# Altium schematic sheet main.SchDoc
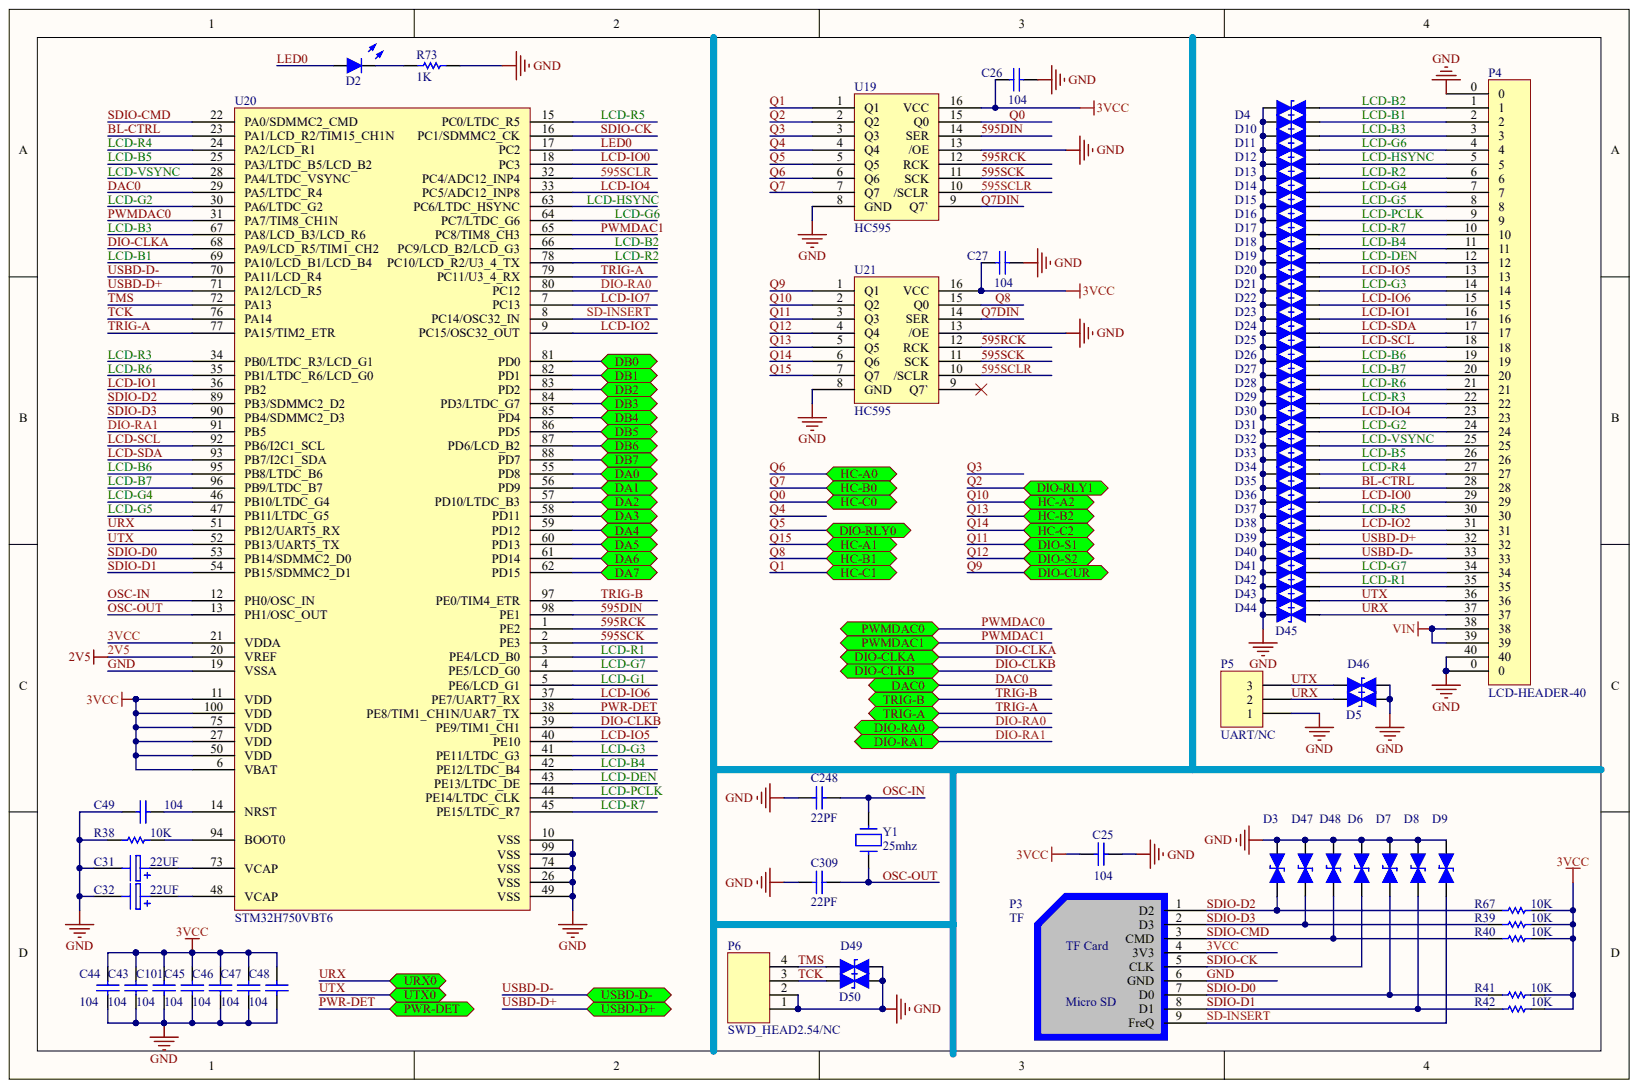
[diagram p0: main.SchDoc]

## Parts
C25  C104  (value not drawn)
C26  C104  (value not drawn)
C27  C104  (value not drawn)
C31  22UF
C32  22UF
C43  C104  (value not drawn)
C44  C104  (value not drawn)
C45  C104  (value not drawn)
C46  C104  (value not drawn)
C47  C104  (value not drawn)
C48  C104  (value not drawn)
C49  C104  (value not drawn)
C101  C104  (value not drawn)
C248  22PF/NC  (value not drawn)
C309  22PF/NC  (value not drawn)
D2  LED-B  (value not drawn)
D3  ESD_0402  (value not drawn)
D4  ESD_0402  (value not drawn)
D5  ESD_0402  (value not drawn)
D6  ESD_0402  (value not drawn)
D7  ESD_0402  (value not drawn)
D8  ESD_0402  (value not drawn)
D9  ESD_0402  (value not drawn)
D10  ESD_0402  (value not drawn)
D11  ESD_0402  (value not drawn)
D12  ESD_0402  (value not drawn)
D13  ESD_0402  (value not drawn)
D14  ESD_0402  (value not drawn)
D15  ESD_0402  (value not drawn)
D16  ESD_0402  (value not drawn)
D17  ESD_0402  (value not drawn)
D18  ESD_0402  (value not drawn)
D19  ESD_0402  (value not drawn)
D20  ESD_0402  (value not drawn)
D21  ESD_0402  (value not drawn)
D22  ESD_0402  (value not drawn)
D23  ESD_0402  (value not drawn)
D24  ESD_0402  (value not drawn)
D25  ESD_0402  (value not drawn)
D26  ESD_0402  (value not drawn)
D27  ESD_0402  (value not drawn)
D28  ESD_0402  (value not drawn)
D29  ESD_0402  (value not drawn)
D30  ESD_0402  (value not drawn)
D31  ESD_0402  (value not drawn)
D32  ESD_0402  (value not drawn)
D33  ESD_0402  (value not drawn)
D34  ESD_0402  (value not drawn)
D35  ESD_0402  (value not drawn)
D36  ESD_0402  (value not drawn)
D37  ESD_0402  (value not drawn)
D38  ESD_0402  (value not drawn)
D39  ESD_0402  (value not drawn)
D40  ESD_0402  (value not drawn)
D41  ESD_0402  (value not drawn)
D42  ESD_0402  (value not drawn)
D43  ESD_0402  (value not drawn)
D44  ESD_0402  (value not drawn)
D45  ESD_0402  (value not drawn)
D46  ESD_0402  (value not drawn)
D47  ESD_0402  (value not drawn)
D48  ESD_0402  (value not drawn)
D49  ESD_0402  (value not drawn)
D50  ESD_0402  (value not drawn)
P3  TF
P4  LCD-HEADER-40
P5  UART/NC
P6  SWD_HEAD2.54/NC
R38  10K
R39  10K
R40  10K
R41  10K
R42  10K
R67  10K
R73  1K
U19  HC595
U20  STM32H750VBT6
U21  HC595
Y1  25mhz

## Ports
DA0, DA1, DA2, DA3, DA4, DA5, DA6, DA7, DAC0, DB0, DB1, DB2, DB3, DB4, DB5, DB6, DB7, DIO-CLKA, DIO-CLKB, DIO-CUR, DIO-RA0, DIO-RA1, DIO-RLY0, DIO-RLY1, DIO-S1, DIO-S2, HC-A0, HC-A1, HC-A2, HC-B0, HC-B1, HC-B2, HC-C0, HC-C1, HC-C2, PWMDAC0, PWMDAC1, PWR-DET, TRIG-A, TRIG-B, URX0, USBD-D+, USBD-D-, UTX0

## Nets
VIN: P4.38 P4.39
2V5: U20.20(VREF)
3VCC: C25.1 C26.1 C27.2 C43.2 C44.2 C45.2 C46.2 C47.2 C48.2 C101.2 P3.4(3V3) R39.2 R40.2 R41.2 R42.2 R67.2 U19.16(VCC) U20.6(VBAT) U20.11(VDD) U20.21(VDDA) U20.27(VDD) U20.50(VDD) U20.75(VDD) U20.100(VDD) U21.16(VCC)
595DIN: U19.14(SER) U20.98(PE1)
595RCK: U19.12(RCK) U20.1(PE2) U21.12(RCK)
595SCK: U19.11(SCK) U20.2(PE3) U21.11(SCK)
595SCLR: U19.10(/SCLR) U20.32(PC4/ADC12_INP4) U21.10(/SCLR)
BL-CTRL: D36.2 P4.28 U20.23(PA1/LCD_R2/TIM15_CH1N)
DA0: U20.55(PD8) ; port DA0
DA1: U20.56(PD9) ; port DA1
DA2: U20.57(PD10/LTDC_B3) ; port DA2
DA3: U20.58(PD11) ; port DA3
DA4: U20.59(PD12) ; port DA4
DA5: U20.60(PD13) ; port DA5
DA6: U20.61(PD14) ; port DA6
DA7: U20.62(PD15) ; port DA7
DAC0: U20.29(PA5/LTDC_R4) ; port DAC0
DB0: U20.81(PD0) ; port DB0
DB1: U20.82(PD1) ; port DB1
DB2: U20.83(PD2) ; port DB2
DB3: U20.84(PD3/LTDC_G7) ; port DB3
DB4: U20.85(PD4) ; port DB4
DB5: U20.86(PD5) ; port DB5
DB6: U20.87(PD6/LCD_B2) ; port DB6
DB7: U20.88(PD7) ; port DB7
DIO-CLKA: U20.68(PA9/LCD_R5/TIM1_CH2) ; port DIO-CLKA
DIO-CLKB: U20.39(PE9/TIM1_CH1) ; port DIO-CLKB
DIO-RA0: U20.80(PC12) ; port DIO-RA0
DIO-RA1: U20.91(PB5) ; port DIO-RA1
GND: C25.2 C26.2 C27.1 C31.2 C32.2 C43.1 C44.1 C45.1 C46.1 C47.1 C48.1 C49.2 C101.1 C248.2 C309.2 D3.1 D4.1 D5.1 D6.1 D7.1 D8.1 D9.1 D10.1 D11.1 D12.1 D13.1 D14.1 D15.1 D16.1 D17.1 D18.1 D19.1 D20.1 D21.1 D22.1 D23.1 D24.1 D25.1 D26.1 D27.1 D28.1 D29.1 D30.1 D31.1 D32.1 D33.1 D34.1 D35.1 D36.1 D37.1 D38.1 D39.1 D40.1 D41.1 D42.1 D43.1 D44.1 D45.1 D46.1 D47.1 D48.1 D49.2 D50.2 P3.6(GND) P4.0 P4.40 P5.1 P6.1 P6.2 R38.1 R73.2 U19.8(GND) U19.13(/OE) U20.10(VSS) U20.19(VSSA) U20.26(VSS) U20.49(VSS) U20.74(VSS) U20.99(VSS) U21.8(GND) U21.13(/OE)
LCD-B1: D10.2 P4.2 U20.69(PA10/LCD_B1/LCD_B4)
LCD-B2: D4.2 P4.1 U20.66(PC9/LCD_B2/LCD_G3)
LCD-B3: D11.2 P4.3 U20.67(PA8/LCD_B3/LCD_R6)
LCD-B4: D19.2 P4.11 U20.42(PE12/LTDC_B4)
LCD-B5: D34.2 P4.26 U20.25(PA3/LTDC_B5/LCD_B2)
LCD-B6: D27.2 P4.19 U20.95(PB8/LTDC_B6)
LCD-B7: D28.2 P4.20 U20.96(PB9/LTDC_B7)
LCD-DEN: D20.2 P4.12 U20.43(PE13/LTDC_DE)
LCD-G1: U20.5(PE6/LCD_G1)
LCD-G2: D32.2 P4.24 U20.30(PA6/LTDC_G2)
LCD-G3: D22.2 P4.14 U20.41(PE11/LTDC_G3)
LCD-G4: D15.2 P4.7 U20.46(PB10/LTDC_G4)
LCD-G5: D16.2 P4.8 U20.47(PB11/LTDC_G5)
LCD-G6: D12.2 P4.4 U20.64(PC7/LTDC_G6)
LCD-G7: D42.2 P4.34 U20.4(PE5/LCD_G0)
LCD-HSYNC: D13.2 P4.5 U20.63(PC6/LTDC_HSYNC)
LCD-IO0: D37.2 P4.29 U20.18(PC3)
LCD-IO1: D24.2 P4.16 U20.36(PB2)
LCD-IO2: D39.2 P4.31 U20.9(PC15/OSC32_OUT)
LCD-IO4: D31.2 P4.23 U20.33(PC5/ADC12_INP8)
LCD-IO5: D21.2 P4.13 U20.40(PE10)
LCD-IO6: D23.2 P4.15 U20.37(PE7/UART7_RX)
LCD-IO7: U20.7(PC13)
LCD-PCLK: D17.2 P4.9 U20.44(PE14/LTDC_CLK)
LCD-R1: D43.2 P4.35 U20.3(PE4/LCD_B0)
LCD-R2: D14.2 P4.6 U20.78(PC10/LCD_R2/U3_4_TX)
LCD-R3: D30.2 P4.22 U20.34(PB0/LTDC_R3/LCD_G1)
LCD-R4: D35.2 P4.27 U20.24(PA2/LCD_R1)
LCD-R5: D38.2 P4.30 U20.15(PC0/LTDC_R5)
LCD-R6: D29.2 P4.21 U20.35(PB1/LTDC_R6/LCD_G0)
LCD-R7: D18.2 P4.10 U20.45(PE15/LTDC_R7)
LCD-SCL: D26.2 P4.18 U20.92(PB6/I2C1_SCL)
LCD-SDA: D25.2 P4.17 U20.93(PB7/I2C1_SDA)
LCD-VSYNC: D33.2 P4.25 U20.28(PA4/LTDC_VSYNC)
LED0: D2.1 U20.17(PC2)
OSC-IN: C248.1 U20.12(PH0/OSC_IN) Y1.1
OSC-OUT: C309.1 U20.13(PH1/OSC_OUT) Y1.3
PWMDAC0: U20.31(PA7/TIM8_CH1N) ; port PWMDAC0
PWMDAC1: U20.65(PC8/TIM8_CH3) ; port PWMDAC1
PWR-DET: U20.38(PE8/TIM1_CH1N/UAR7_TX) ; port PWR-DET
Q0: U19.15(Q0) ; port HC-C0
Q1: U19.1(Q1) ; port HC-C1
Q2: U19.2(Q2) ; port DIO-RLY1
Q3: U19.3(Q3)
Q4: U19.4(Q4)
Q5: U19.5(Q5) ; port DIO-RLY0
Q6: U19.6(Q6) ; port HC-A0
Q7: U19.7(Q7) ; port HC-B0
Q7DIN: U19.9(Q7`) U21.14(SER)
Q8: U21.15(Q0) ; port HC-B1
Q9: U21.1(Q1) ; port DIO-CUR
Q10: U21.2(Q2) ; port HC-A2
Q11: U21.3(Q3) ; port DIO-S1
Q12: U21.4(Q4) ; port DIO-S2
Q13: U21.5(Q5) ; port HC-B2
Q14: U21.6(Q6) ; port HC-C2
Q15: U21.7(Q7) ; port HC-A1
SD-INSERT: D9.2 P3.9(FreQ) U20.8(PC14/OSC32_IN)
SDIO-CK: D6.2 P3.5(CLK) U20.16(PC1/SDMMC2_CK)
SDIO-CMD: D48.2 P3.3(CMD) R40.1 U20.22(PA0/SDMMC2_CMD)
SDIO-D0: D7.2 P3.7(D0) R41.1 U20.53(PB14/SDMMC2_D0)
SDIO-D1: D8.2 P3.8(D1) R42.1 U20.54(PB15/SDMMC2_D1)
SDIO-D2: D3.2 P3.1(D2) R67.1 U20.89(PB3/SDMMC2_D2)
SDIO-D3: D47.2 P3.2(D3) R39.1 U20.90(PB4/SDMMC2_D3)
TCK: D50.1 P6.3 U20.76(PA14)
TMS: D49.1 P6.4 U20.72(PA13)
TRIG-A: U20.77(PA15/TIM2_ETR) U20.79(PC11/U3_4_RX) ; port TRIG-A
TRIG-B: U20.97(PE0/TIM4_ETR) ; port TRIG-B
URX: D5.2 D45.2 P4.37 P5.2 U20.51(PB12/UART5_RX) ; port URX0
USBD-D+: D40.2 P4.32 U20.71(PA12/LCD_R5) ; port USBD-D+
USBD-D-: D41.2 P4.33 U20.70(PA11/LCD_R4) ; port USBD-D-
UTX: D44.2 D46.2 P4.36 P5.3 U20.52(PB13/UART5_TX) ; port UTX0
NetC31_1: C31.1 U20.73(VCAP)
NetC32_1: C32.1 U20.48(VCAP)
NetC49_1: C49.1 U20.14(NRST)
NetD2_2: D2.2 R73.1
NetR38_2: R38.2 U20.94(BOOT0)

Not connected: U21 9(Q7`)

## Library data of the parts
C25-C27 C31 C32 C43-C49 C101 C248 C309 D2-D50 P3-P6 R38-R42 R67 R73 U19-U21 Y1 = (no symbol name)
  C25-C27 C43-C49 C101: : 104
  C31 C32: : 22UF
  C248 C309: : 22PF
  P5: LatestRevisionDate: 17-Jul-2002; LatestRevisionNote: Re-released for DXP Platform.; Publisher: Altium Limited
  R38-R42 R67: : 10K
  R73: : 1K
